# Altium schematic sheet TEmpSensor_Sheet1.SchDoc
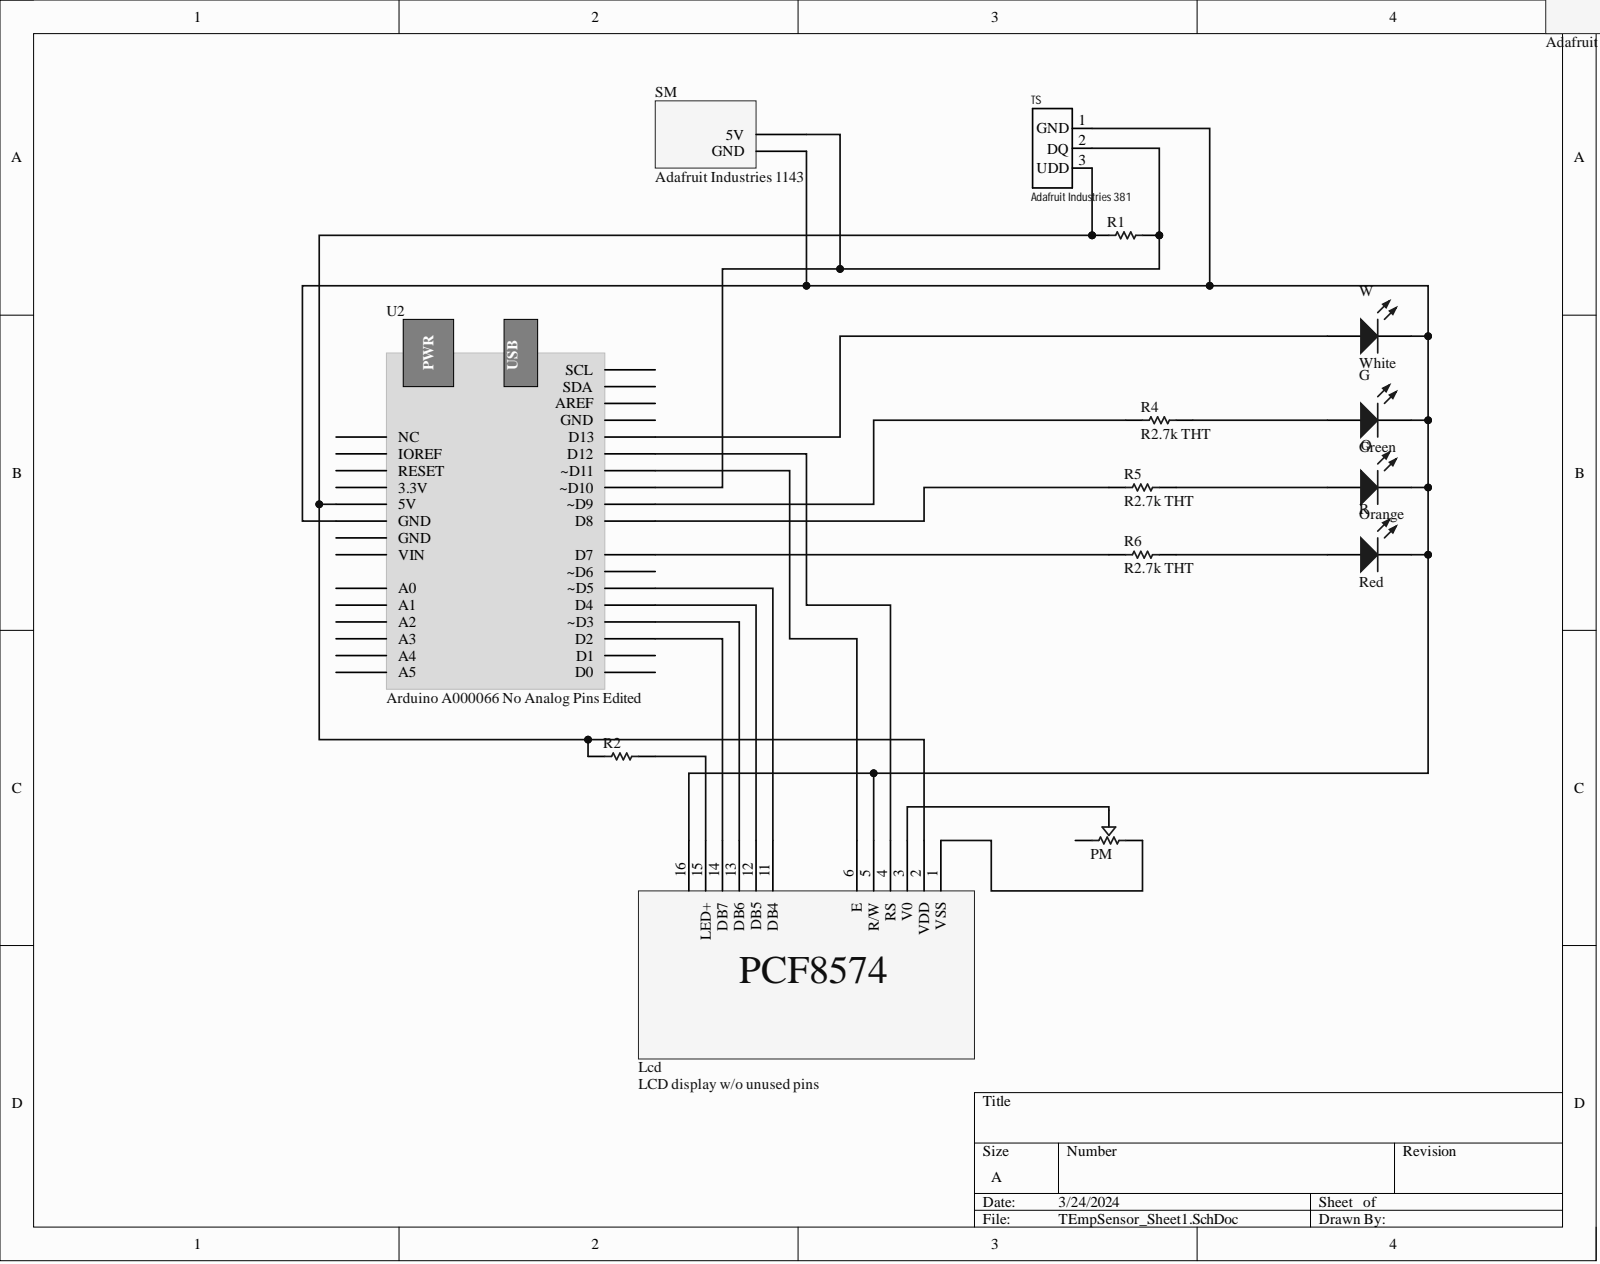
[diagram p0: TEmpSensor_Sheet1.SchDoc]

## Parts
*  Adafruit Industries 1143  [Adafruit Industries 1143-Footprint-1]
G  Green  [PCB-uzur084zw2lo12w1tw7w-1]
Lcd  LCD display w/o unused pins  [Adafruit Industries 181-Footprint-1]
O  Orange  [PCB-uzur084zw2lo12w1tw7w-1]
PM  (no value)  [PCB-0kr9eu6zp7fhutysqv4z-1]
R  Red  [PCB-uzur084zw2lo12w1tw7w-1]
R1  (no value)
R2  (no value)
R4  R2.7k THT  [PCB-gfkc8mq71fa2o4qnwuok-1]
R5  R2.7k THT  [PCB-gfkc8mq71fa2o4qnwuok-1]
R6  R2.7k THT  [PCB-gfkc8mq71fa2o4qnwuok-1]
SM  Adafruit Industries 1143  Manufacturer Part Number: 1143  [Adafruit Industries 1143-Footprint-1]
TS  Adafruit Industries 381  [PCB-4jkftp4g9jgygak3ejkg-1]
U2  Arduino A000066 No Analog Pins Edited  Manufacturer Part Number: A000066  [PCB-5e3n8s0ey5jqr2f9nncc-1]
W  White  [PCB-uzur084zw2lo12w1tw7w-1]

## Nets
NetG_1: G.1 R4.2
NetG_2: G.2 Lcd.5(R/W) Lcd.16 O.2 R.2 SM.3 TS.1 U2.GND1(GND) W.2
NetLcd_1: Lcd.1(VSS) PM.3
NetLcd_2: Lcd.2(VDD) R1.1 R2.1 TS.3 U2.5V
NetLcd_3: Lcd.3(V0) PM.2
NetLcd_4: Lcd.4(RS) U2.D12
NetLcd_6: Lcd.6(E) U2.D11(~D11)
NetLcd_11: Lcd.11(DB4) U2.D5(~D5)
NetLcd_12: Lcd.12(DB5) U2.D4
NetLcd_13: Lcd.13(DB6) U2.D3(~D3)
NetLcd_14: Lcd.14(DB7) U2.D2
NetLcd_15: Lcd.15(LED+) R2.2
NetO_1: O.1 R5.2
NetR1_2: R1.2 SM.2 TS.2 U2.D10(~D10)
NetR4_1: R4.1 U2.D9(~D9)
NetR5_1: R5.1 U2.D8
NetR6_1: R6.1 U2.D7
NetR6_2: R.1 R6.2
NetU2_D13: U2.D13 W.1

Not connected: * 2 3; PM 1; U2 3.3V A0 A1 A2 A3 A4 A5 AREF D0 D1 D6(~D6) GND2(GND) GND3(GND) IOREF NC RESET SCL SDA VIN

## Library data of the parts
* = CMP-b4f47c09a4886b33-4: Servo Motors DC Motor 6VDC 0.215N.m 1Shaft; Manufacturer Part Number: 1143; Component Kind: Standard; Library Name: My Personal Space; Octopart Url: https://octopart.com/1143-adafruit+industries-32978202; OctopartID: b4f47c09a4886b33
G O R W = CMP-2813e115ffe4f7d4-2: LED-5mm Super White Water Clear Lens 16000 Mcd; Manufacturer Part Number: NTE30045; Forward Voltage: 3.60 V; Viewing Angle: 22 °; Component Kind: Standard; Library Name: My Personal Space; Mounting Style: Through Hole; Number of Pins: 2; Octopart Url: https://octopart.com/nte30045-nte+electronics-848529; OctopartID: 2813e115ffe4f7d4; RoHS: Compliant
Lcd = CMP-612da9d07244beea-8: Manufacturer Part Number: 181; Cable Length: 31.0 mm; Gender: Male; Lead-Free Status: Lead Free; Number of Positions: 2; Color: Black; Component Kind: Standard; Contact Plating: Nickel; Library Name: My Personal Space; Material: Brass; Octopart URL: https://octopart.com/181-adafruit+industries-32978447; OctopartID: 612da9d07244beea; RoHS: Compliant; Size-Diameter: 11.1 mm; Size-Length: 14.2 mm
PM = CMP-7a92b0d527a5a555-1: Potentiometers, Encoders and Resolvers, Potentiometers, Conductive Plastic; Manufacturer Part Number: 53C150K; Power Rating: 2.00 W; Resistance: 50.0 kΩ; Resistance Tolerance: ±10%; Component Kind: Standard; Library Name: My Personal Space; Mounting Style: Surface Mount, Solder Lug; Octopart URL: https://octopart.com/53c150k-honeywell-55408721; OctopartID: 7a92b0d527a5a555; Operating Temperature: 105 °C (max); Packaging: Bulk; RoHS: Compliant; Size-Diameter: 25.4 mm; Size-Length: 14.3 mm
R1 R2 = CMP-0837c35e67d7d7f0-1: Through Hole Resistor, Rox Series, 220 Ohm, 1 W, 5%, 350 V, Axial Leaded Rohs Compliant: Yes; Manufacturer Part Number: 4-1625885-4; Lead Length: 28.0 mm; Power Rating: 1.00 W; Resistance: 220 Ω; Temperature Coefficient: -350 ppm/°C to 350 ppm/°C; Voltage Rating (DC): 350 V; Component Kind: Standard; ELV Compliant: Compliant; Library Name: My Personal Space; Lifecycle Status: Active; Mounting Style: Axial; Number of Pins: 2; Octopart URL: https://octopart.com/4-1625885-4-te+connectivity-40061574; OctopartID: 0837c35e67d7d7f0; Operating Temperature: -55.0 °C to 235 °C; Packaging: Ammo Pack; REACH SVHC Compliance: No SVHC; Resistance Tolerance: ±5%; RoHS: Compliant
R4-R6 = CMP-610ca9363a79becb-46: Resistor; Resistance 2.7k Ohms, THT; School: CKZ; Component Kind: Standard; Library Name: My Personal Space; resistance: 2.7k ohms
SM = CMP-b4f47c09a4886b33-4: Servo Motors DC Motor 6VDC 0.215N.m 1Shaft; Component Kind: Standard; Library Name: My Personal Space; Octopart Url: https://octopart.com/1143-adafruit+industries-32978202; OctopartID: b4f47c09a4886b33
TS = CMP-0e45c6b81da8d182-1: Adafruit Waterproof DS18B20 Digital temperature sensor + extras; Manufacturer Part Number: 381; Component Kind: Standard; Library Name: My Personal Space; Octopart Url: https://octopart.com/381-adafruit+industries-32978571; OctopartID: 0e45c6b81da8d182
U2 = CMP-1073962-113: Microcontroller board, Uno [Arduino] A000066 Microcontroller board, Uno 7640152110092; Core Architecture: AVR; Number of Bits: 8; Component Kind: Standard; Library Name: My Personal Space; Octopart URL: http://octopart.com/a000066-arduino-21222240; OctopartID: aa24626d5c2275e3; REACH SVHC Compliance: No SVHC; RoHS: Compliant
